# Altium schematic sheet [5]-USB.SchDoc
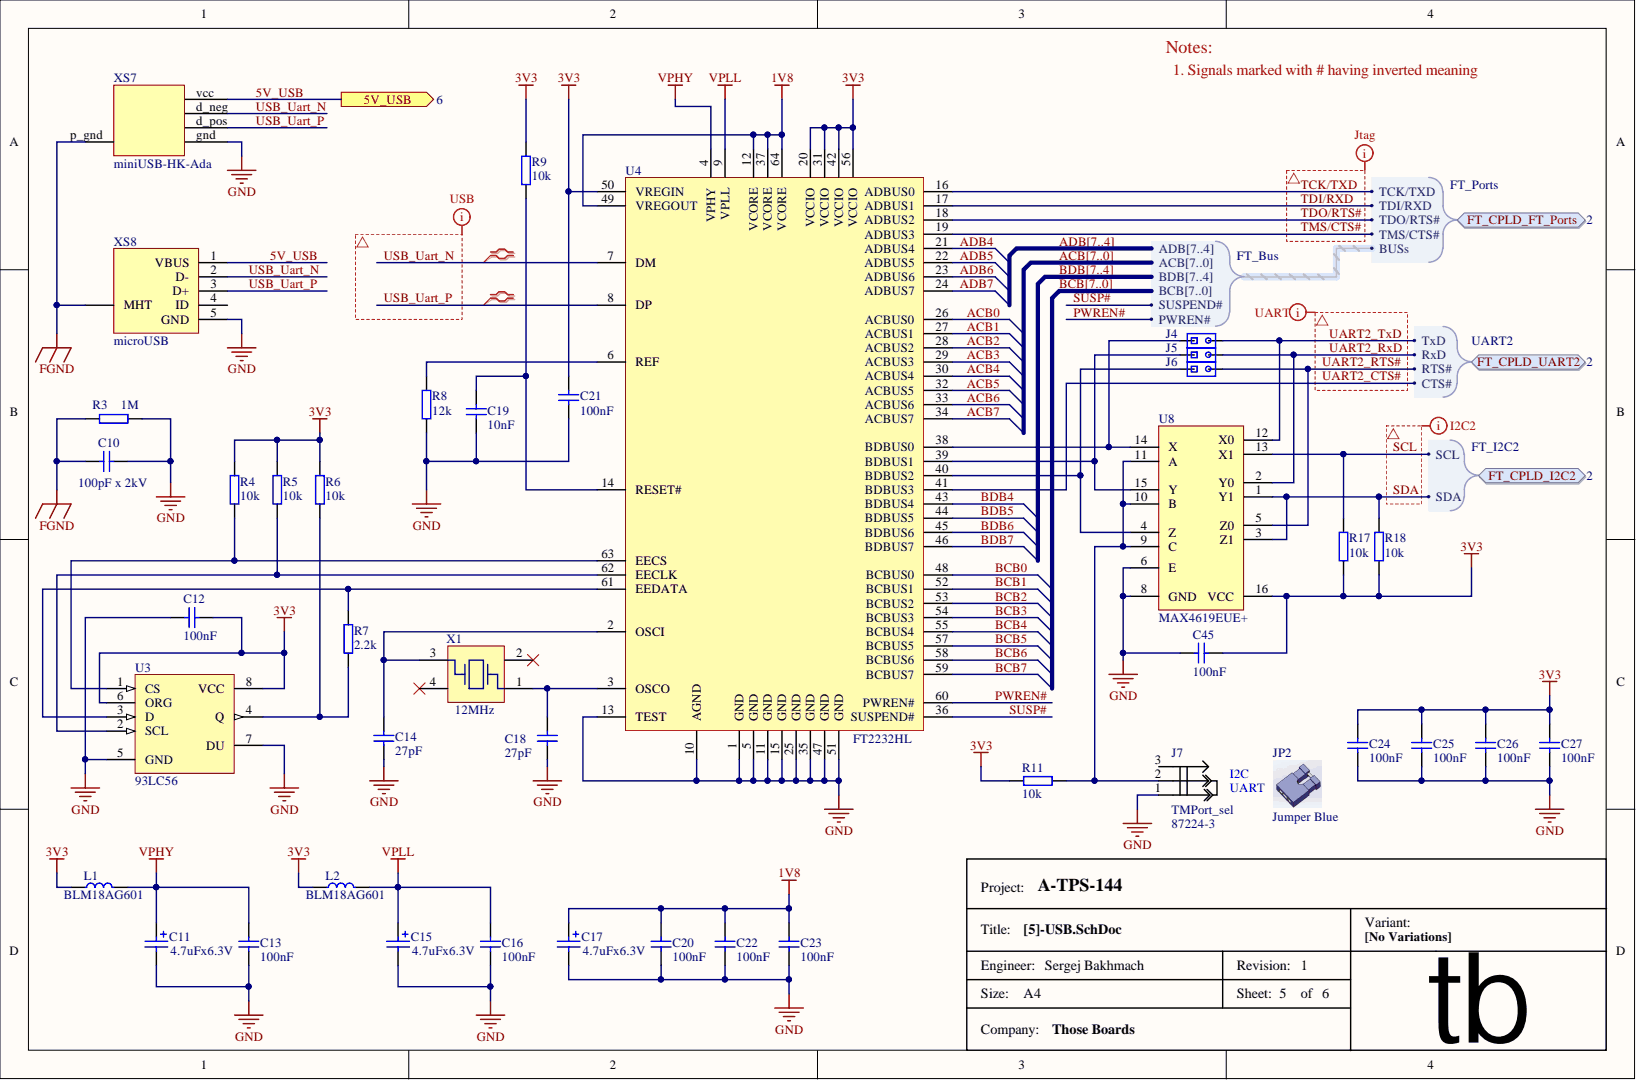
[diagram p0: [5]-USB.SchDoc]

## Parts
C10  value 100pF x 2kV  [C1210]  (hidden comment: CAP)
C11  value 4.7uFx6.3V  [CAP TAN 0805 2012 SIZE R]  (hidden comment: uF)
C12  value 100nF  [C0603]  (hidden comment: CAP)
C13  value 100nF  [C0603]  (hidden comment: CAP)
C14  value 27pF  [C0603]  (hidden comment: CAP)
C15  value 4.7uFx6.3V  [CAP TAN 0805 2012 SIZE R]  (hidden comment: uF)
C16  value 100nF  [C0603]  (hidden comment: CAP)
C17  value 4.7uFx6.3V  [CAP TAN 0805 2012 SIZE R]  (hidden comment: uF)
C18  value 27pF  [C0603]  (hidden comment: CAP)
C19  value 10nF  [C0603]  (hidden comment: CAP)
C20  value 100nF  [C0603]  (hidden comment: CAP)
C21  value 100nF  [C0603]  (hidden comment: CAP)
C22  value 100nF  [C0603]  (hidden comment: CAP)
C23  value 100nF  [C0603]  (hidden comment: CAP)
C24  value 100nF  [C0603]  (hidden comment: CAP)
C25  value 100nF  [C0603]  (hidden comment: CAP)
C26  value 100nF  [C0603]  (hidden comment: CAP)
C27  value 100nF  [C0603]  (hidden comment: CAP)
C45  value 100nF  [C0603]  (hidden comment: CAP)
J4  (no value)  [Jumper_smd_d3]  (no BOM)
J5  (no value)  [Jumper_smd_d3]  (no BOM)
J6  (no value)  [Jumper_smd_d3]  (no BOM)
J7  TMPort_sel  value 87224-3  Manufacturer Part: 87224-3  [Jumper_3pin]
JP2  value Jumper Blue  Supplier Part: Jumper  (hidden comment: VCC_sel)  (mechanical)
L1  value BLM18AG601  [ferrite_bead_0603]  (hidden comment: BLM18PG221SN1D)
L2  value BLM18AG601  [ferrite_bead_0603]  (hidden comment: BLM18PG221SN1D)
R3  value 1M  [0805_resistor]  (hidden comment: Resistor)
R4  value 10k  [0603_RESISTOR]  (hidden comment: Resistor)
R5  value 10k  [0603_RESISTOR]  (hidden comment: Resistor)
R6  value 10k  [0603_RESISTOR]  (hidden comment: Resistor)
R7  value 2.2k  [0603_RESISTOR]  (hidden comment: Resistor)
R8  value 12k  [0603_RESISTOR]  (hidden comment: Resistor)
R9  value 10k  [0603_RESISTOR]  (hidden comment: Resistor)
R11  value 10k  [0603_RESISTOR]  (hidden comment: Resistor)
R17  value 10k  [0603_RESISTOR]  (hidden comment: Resistor)
R18  value 10k  [0603_RESISTOR]  (hidden comment: Resistor)
U3  value 93LC56  [SOIC-8 150 Mil]  (hidden comment: 93xCxx)
U4  FT2232HL  [LQFP64]
U8  MAX4619EUE+  [TSSOP-16]
X1  value 12MHz  [OSC_CX3225GB]  (hidden comment: OSC_CX3225GB)
XS7  value miniUSB-HK-Ada  Manufacturer Part: miniUSB-HK-Ada  [MiniUSB_Conn_2types]  (hidden comment: miniUSB_conn)
XS8  microUSB  [MicroUSB47642-0001]

## Ports
5V_USB, FT_CPLD_FT_PORTS, FT_CPLD_FT_PORTS.BUSS.ACB0, FT_CPLD_FT_PORTS.BUSS.ACB1, FT_CPLD_FT_PORTS.BUSS.ACB2, FT_CPLD_FT_PORTS.BUSS.ACB3, FT_CPLD_FT_PORTS.BUSS.ACB4, FT_CPLD_FT_PORTS.BUSS.ACB5, FT_CPLD_FT_PORTS.BUSS.ACB6, FT_CPLD_FT_PORTS.BUSS.ACB7, FT_CPLD_FT_PORTS.BUSS.ACB[7..0], FT_CPLD_FT_PORTS.BUSS.ADB4, FT_CPLD_FT_PORTS.BUSS.ADB5, FT_CPLD_FT_PORTS.BUSS.ADB6, FT_CPLD_FT_PORTS.BUSS.ADB7, FT_CPLD_FT_PORTS.BUSS.ADB[7..4], FT_CPLD_FT_PORTS.BUSS.BCB0, FT_CPLD_FT_PORTS.BUSS.BCB1, FT_CPLD_FT_PORTS.BUSS.BCB2, FT_CPLD_FT_PORTS.BUSS.BCB3, FT_CPLD_FT_PORTS.BUSS.BCB4, FT_CPLD_FT_PORTS.BUSS.BCB5, FT_CPLD_FT_PORTS.BUSS.BCB6, FT_CPLD_FT_PORTS.BUSS.BCB7, FT_CPLD_FT_PORTS.BUSS.BCB[7..0], FT_CPLD_FT_PORTS.BUSS.BDB4, FT_CPLD_FT_PORTS.BUSS.BDB5, FT_CPLD_FT_PORTS.BUSS.BDB6, FT_CPLD_FT_PORTS.BUSS.BDB7, FT_CPLD_FT_PORTS.BUSS.BDB[7..4], FT_CPLD_FT_PORTS.BUSS.PWREN#, FT_CPLD_FT_PORTS.BUSS.SUSPEND#, FT_CPLD_FT_PORTS.TCK/TXD, FT_CPLD_FT_PORTS.TDI/RXD, FT_CPLD_FT_PORTS.TDO/RTS#, FT_CPLD_FT_PORTS.TMS/CTS#, FT_CPLD_I2C2, FT_CPLD_I2C2.SCL, FT_CPLD_I2C2.SDA, FT_CPLD_UART2, FT_CPLD_UART2.CTS#, FT_CPLD_UART2.RTS#, FT_CPLD_UART2.RXD, FT_CPLD_UART2.TXD

## Nets
1V8: C17.1 C20.2 C22.2 C23.2 U4.12(VCORE) U4.37(VCORE) U4.49(VREGOUT) U4.64(VCORE)
3V3: C12.1 C21.2 C24.2 C25.2 C26.2 C27.2 C45.1 L1.1 L2.1 R4.2 R5.2 R6.2 R9.2 R11.2 R17.1 R18.1 U3.6(ORG) U3.8(VCC) U4.20(VCCIO) U4.31(VCCIO) U4.42(VCCIO) U4.50(VREGIN) U4.56(VCCIO) U8.16(VCC)
FGND: C10.1 R3.1 XS7.p_gnd XS8.MHT
GND: C10.2 C11.2 C12.2 C13.1 C14.1 C15.2 C16.1 C17.2 C18.1 C19.1 C20.1 C21.1 C22.1 C23.1 C24.1 C25.1 C26.1 C27.1 C45.2 J7.1 R3.2 R8.1 U3.5(GND) U3.7(DU) U4.1(GND) U4.5(GND) U4.10(AGND) U4.11(GND) U4.13(TEST) U4.15(GND) U4.25(GND) U4.35(GND) U4.47(GND) U4.51(GND) U8.6(E) U8.8(GND) XS7.gnd XS8.5(GND)
VPHY: C11.1 C13.2 L1.2 U4.4(VPHY)
VPLL: C15.1 C16.2 L2.2 U4.9(VPLL)
5V_USB: XS7.vcc XS8.1(VBUS) ; port 5V_USB
ACB0: U4.26(ACBUS0) ; port FT_CPLD_FT_PORTS  [also labelled ACB]
ACB1: U4.27(ACBUS1) ; port FT_CPLD_FT_PORTS  [also labelled ACB]
ACB2: U4.28(ACBUS2) ; port FT_CPLD_FT_PORTS  [also labelled ACB]
ACB3: U4.29(ACBUS3) ; port FT_CPLD_FT_PORTS  [also labelled ACB]
ACB4: U4.30(ACBUS4) ; port FT_CPLD_FT_PORTS  [also labelled ACB]
ACB5: U4.32(ACBUS5) ; port FT_CPLD_FT_PORTS  [also labelled ACB]
ACB6: U4.33(ACBUS6) ; port FT_CPLD_FT_PORTS  [also labelled ACB]
ACB7: U4.34(ACBUS7) ; port FT_CPLD_FT_PORTS  [also labelled ACB]
ADB4: U4.21(ADBUS4) ; port FT_CPLD_FT_PORTS  [also labelled ADB]
ADB5: U4.22(ADBUS5) ; port FT_CPLD_FT_PORTS  [also labelled ADB]
ADB6: U4.23(ADBUS6) ; port FT_CPLD_FT_PORTS  [also labelled ADB]
ADB7: U4.24(ADBUS7) ; port FT_CPLD_FT_PORTS  [also labelled ADB]
BCB0: U4.48(BCBUS0) ; port FT_CPLD_FT_PORTS  [also labelled BCB]
BCB1: U4.52(BCBUS1) ; port FT_CPLD_FT_PORTS  [also labelled BCB]
BCB2: U4.53(BCBUS2) ; port FT_CPLD_FT_PORTS  [also labelled BCB]
BCB3: U4.54(BCBUS3) ; port FT_CPLD_FT_PORTS  [also labelled BCB]
BCB4: U4.55(BCBUS4) ; port FT_CPLD_FT_PORTS  [also labelled BCB]
BCB5: U4.57(BCBUS5) ; port FT_CPLD_FT_PORTS  [also labelled BCB]
BCB6: U4.58(BCBUS6) ; port FT_CPLD_FT_PORTS  [also labelled BCB]
BCB7: U4.59(BCBUS7) ; port FT_CPLD_FT_PORTS  [also labelled BCB]
BDB4: U4.43(BDBUS4) ; port FT_CPLD_FT_PORTS  [also labelled BDB]
BDB5: U4.44(BDBUS5) ; port FT_CPLD_FT_PORTS  [also labelled BDB]
BDB6: U4.45(BDBUS6) ; port FT_CPLD_FT_PORTS  [also labelled BDB]
BDB7: U4.46(BDBUS7) ; port FT_CPLD_FT_PORTS  [also labelled BDB]
PWREN#: U4.60(PWREN#) ; port FT_CPLD_FT_PORTS
SCL: R17.2 U8.13(X1) ; port FT_CPLD_I2C2
SDA: R18.2 U8.1(Y1) U8.3(Z1) ; port FT_CPLD_I2C2
SUSP#: U4.36(SUSPEND#) ; port FT_CPLD_FT_PORTS
TCK/TXD: U4.16(ADBUS0) ; port FT_CPLD_FT_PORTS
TDI/RXD: U4.17(ADBUS1) ; port FT_CPLD_FT_PORTS
TDO/RTS#: U4.18(ADBUS2) ; port FT_CPLD_FT_PORTS
TMS/CTS#: U4.19(ADBUS3) ; port FT_CPLD_FT_PORTS
UART2_CTS#: U4.41(BDBUS3) ; port FT_CPLD_UART2
UART2_RTS#: J6.2 U8.5(Z0) ; port FT_CPLD_UART2
UART2_RXD: J5.2 U8.2(Y0) ; port FT_CPLD_UART2
UART2_TXD: J4.2 U8.12(X0) ; port FT_CPLD_UART2
USB_UART_N: U4.7(DM) XS7.d_neg XS8.2(D-)
USB_UART_P: U4.8(DP) XS7.d_pos XS8.3(D+)
NetC14_2: C14.2 U4.2(OSCI) X1.3
NetC18_2: C18.2 U4.3(OSCO) X1.1
NetC19_2: C19.2 R9.1 U4.14(RESET#)
NetJ4_1: J4.1 U4.38(BDBUS0) U8.14(X)
NetJ5_1: J5.1 U4.39(BDBUS1) U8.15(Y)
NetJ6_1: J6.1 U4.40(BDBUS2) U8.4(Z)
NetJ7_2: J7.2 R11.1 U8.9(C) U8.10(B) U8.11(A)
NetR4_1: R4.1 U3.1(CS) U4.63(EECS)
NetR5_1: R5.1 U3.2(SCL) U4.62(EECLK)
NetR6_1: R6.1 R7.1 U3.4(Q)
NetR7_2: R7.2 U3.3(D) U4.61(EEDATA)
NetR8_2: R8.2 U4.6(REF)
N00136:
N00137:
N00138:
N00139:

Not connected: J7 3; X1 2 4; XS8 4(ID)

## Library data of the parts
C10 = CAP: Manufacturer: Murata Electronics; Manufacturer Part: GRM32A7U3D101JW31D; Type of Component: Ceramic Capacitor; Component Kind: Standard; Manufacturer Link: http://psearch.en.murata.com/capacitor/product/GRM32A7U3D101JW31%23.html; Package / Case: 1210; Supplier: mouser; Supplier Link: http://www.mouser.ee/ProductDetail/Murata-Electronics/GRM32A7U3D101JW31D/?qs=sGAEpiMZZMs0AnBnWHyRQFQYdTDrJniYFw4lyPz2MF4%3d; Supplier Part: 81-GRM32A7U3D101JW31; Library Name: RPI-VITA.IntLib
C11 C15 C17 = Cap_pol_tant: Manufacturer: AVX; Manufacturer Part: TAJR475K006RNJ; Type of Component: Tantalum Capacitor; Component Kind: Standard; Manufacturer Link: https://www.avx.com/prodinfo_productdetail.asp?I=81&K=search&C=&word=TAJR475K006RNJ&form=number&Number=; Package / Case: 0805; Supplier: mouser; Supplier Link: http://www.mouser.com/ProductDetail/AVX/TAJR475K006RNJ/?qs=sGAEpiMZZMuEN2agSAc2pmRv4r8cj6yFV16HxCaO%252bYg%3d; Supplier Part: 581-TAJR475K006RNJ; Library Name: RPI-VITA.IntLib
C12 C13 C16 C20-C27 C45 = CAP: Manufacturer: Vishay / Vitramon; Manufacturer Part: VJ0603Y104JXJPW1BC; Type of Component: Ceramic Capacitor; Component Kind: Standard; Manufacturer Link: http://www.vishay.com/product?docid=28548; Package / Case: 0603; Supplier: mouser; Supplier Link: http://www.mouser.com/ProductDetail/Vishay/VJ0603Y104JXJPW1BC/?qs=%2fha2pyFaduidGoO0X7aK7Xgs%2fjxfhnLiK6SRw4Lz0%252bZK3PJ8DddTrQ%3d%3d; Supplier Part: 77-VJ0603Y104JXJPBC; Library Name: RPI-VITA.IntLib
C14 C18 = CAP: Manufacturer: Vishay / Vitramon; Manufacturer Part: VJ0603A270GXAPW1BC; Type of Component: Ceramic Capacitor; Component Kind: Standard; Manufacturer Link: http://www.vishay.com/product?docid=28548; Package / Case: 0603; Supplier: mouser; Supplier Link: http://www.mouser.com/ProductDetail/Vishay-Vitramon/VJ0603A270GXAPW1BC/?qs=sGAEpiMZZMs0AnBnWHyRQCv4vfTUG%2fvHq9VjSt3ox9g%3d; Supplier Part: 77-VJ0603A270GXAPBC; Library Name: RPI-VITA.IntLib
C19 = CAP: Manufacturer: Vishay / Vitramon; Manufacturer Part: VJ0603Y103KXACW1BC; Type of Component: Ceramic Capacitor; Component Kind: Standard; Manufacturer Link: http://www.vishay.com/product?docid=28548; Package / Case: 0603; Supplier: mouser; Supplier Link: http://www.mouser.com/ProductDetail/Vishay-Vitramon/VJ0603Y103KXACW1BC/?qs=sGAEpiMZZMs0AnBnWHyRQNbg85K4ab%2f3XqRx2jQHGsc%3d; Supplier Part: 77-VJ0603Y103KXACBC; Library Name: RPI-VITA.IntLib
J4-J6 = jumper_2pin_pads: Library Name: RPI-VITA.IntLib
J7 = jumper_3pin: Manufacturer: TE Connectivity / AMP; Type of Component: Jumper; Component Kind: Standard; Manufacturer Link: http://www.te.com/usa-en/product-87224-3.html#pdp-related-products-inner; Package / Case: Header 1 x 3; Supplier: mouser; Supplier Link: http://www.mouser.ee/ProductDetail/TE-Connectivity-AMP/87224-3/?qs=sGAEpiMZZMs%252bGHln7q6pm48SVpWlpfsErguO%2fp0873w%3d; Supplier Part: 571-872243; Library Name: RPI-VITA.IntLib
JP2 = jumper blue: Manufacturer: Great Electric; Manufacturer Part: Jumper Cap Shunts Short Circuit Cap; Package / Case: 2.54mm; Supplier: aliexpress.com; Supplier Link: http://www.aliexpress.com/item/500pcs-lot-Asssorted-Color-2-54mm-Standard-Circuit-Board-Jumper-Cap-Shunts-Short-Circuit-Cap-CGKCH119/32344305619.html?spm=2114.03010208.3.80.nPPmoy&ws_ab_test=searchweb201556_1,searchweb201644_1_505_506_503_504_502_10001_10002_10017_10010_10005_10011_10006_10003_10004_10009_10007_10008,searchweb201560_5,searchweb1451318400_-1,searchweb1451318411_6452&btsid=16d11777-edde-4755-b8b5-9adacb495b09; Type of Component: Jumper; Library Name: RPI-VITA.IntLib
L1 L2 = BLM18PG221SN1D: ferrite bead 0603; Manufacturer: Murata; Manufacturer Part: BLM18AG601SN1D; Type of Component: EMI Filter Beads; Component Kind: Standard; Manufacturer Link: http://search.murata.co.jp/Ceramy/CatalogAction.do?sHinnm=BLM11A601S&sNHinnm=BLM18AG601SN1&sNhin_key=BLM18AG601SN1D&sLang=en&sParam=BLM18AG601SN1D; Package / Case: 0603; Supplier: mouser; Supplier Link: http://www.mouser.com/ProductDetail/Murata/BLM18AG601SN1D/?qs=%2fha2pyFadugaXrdTm9z6ujoHK9ziqVaZDl9lDPSbK0ptDeQWgq1exg%3d%3d; Supplier Part: 81-BLM11A601S; Library Name: RPI-VITA.IntLib
R3 = Resistor: Manufacturer: Vishay / Dale; Manufacturer Part: CRCW08051M00FKEA; Component Kind: Standard; Manufacturer Link: http://www.vishay.com/product?docid=20035; Package / Case: 0805; Supplier: mouser; Supplier Link: http://www2.mouser.com/ProductDetail/Vishay-Dale/CRCW08051M00FKEA/?qs=sGAEpiMZZMvdGkrng054txEw7b1YnvGubiAZzjveInE%3d; Supplier Part: 71-CRCW0805-1.0M-E3; Type of Component: Resistor; Library Name: RPI-VITA.IntLib
R4-R6 R9 R11 R17 R18 = Resistor: Manufacturer: Vishay / Dale; Manufacturer Part: CRCW060310K0FKEA; Component Kind: Standard; Manufacturer Link: http://www.vishay.com/product?docid=20035; Package / Case: 0603; Supplier: mouser; Supplier Link: http://www.mouser.com/ProductDetail/Vishay-Dale/CRCW060310K0FKEA/?qs=sGAEpiMZZMu61qfTUdNhGyptJL5EcUtREGTsEKG7Lak%3d; Supplier Part: 71-CRCW0603-10K-E3; Type of Component: Resistor; Library Name: RPI-VITA.IntLib
R7 = Resistor: Manufacturer: Vishay / Dale; Manufacturer Part: CRCW06032K20JNEA; Component Kind: Standard; Manufacturer Link: http://www.vishay.com/product?docid=20035; Package / Case: 0603; Supplier: mouser; Supplier Link: http://www.mouser.com/ProductDetail/Vishay-Dale/CRCW06032K20JNEA/?qs=sGAEpiMZZMvdGkrng054txEw7b1YnvGuRJLBQI6feSk%3d; Supplier Part: 71-CRCW0603J-2.2K-E3; Type of Component: Resistor; Library Name: RPI-VITA.IntLib
R8 = Resistor: Manufacturer: Vishay / Dale; Manufacturer Part: CRCW060312K0FKEA; Component Kind: Standard; Manufacturer Link: http://www.vishay.com/product?docid=20035; Package / Case: 0603; Supplier: mouser; Supplier Link: http://www.mouser.com/ProductDetail/Vishay-Dale/CRCW060312K0FKEA/?qs=sGAEpiMZZMu61qfTUdNhG%2fy1ga03FwuG5%2fz6Ysnsyas%3d; Supplier Part: 71-CRCW0603-12K-E3; Type of Component: Resistor; Library Name: RPI-VITA.IntLib
U3 = 93xCxx: eeprom; Manufacturer: Microchip Technology; Manufacturer Part: 93LC56CT-I/SN; Component Kind: Standard; Manufacturer Link: http://www.microchip.com/wwwproducts/Devices.aspx?product=93LC56C; Package / Case: SOIC-8; Supplier: mouser; Supplier Link: http://www.mouser.com/ProductDetail/Microchip-Technology/93LC56CT-I-SN/?qs=sGAEpiMZZMuVhdAcoizlRTtWxdtwgs5NlmtRRTBFNKE%3d; Supplier Part: 579-93LC56CT-I/SN; Type of Component: EEPROM; Library Name: RPI-VITA.IntLib
U4 = FT2232HL: Manufacturer: FTDI; Manufacturer Part: FT2232HL-TRAY; Type of Component: USB Interface IC; Component Kind: Standard; Manufacturer Link: http://www.ftdichip.com/Products/ICs/FT2232H.htm; Package / Case: LQFP-64; Supplier: mouser; Supplier Link: http://www.mouser.com/ProductDetail/FTDI/FT2232HL-TRAY/?qs=sGAEpiMZZMtv%252bwxsgy%2fhiMKIrlJio50yoVwto4siX0c%3d; Supplier Part: 895-FT2232HL-TRAY; Value: FT2232HL; Library Name: RPI-VITA.IntLib
U8 = MAX4619EUE+: High-Speed, Low-Voltage, CMOS Analog  Multiplexers/Switches; Manufacturer: Maxim Integrated; Type of Component: Analog Switch IC; Component Kind: Standard; Manufacturer Link: https://www.maximintegrated.com/en/products/analog/analog-switches-multiplexers/MAX4619.html; Manufacturer Part: MAX4619EUE+; Package / Case: TSSOP-16; Supplier: mouser; Supplier Link: http://www2.mouser.com/ProductDetail/Maxim-Integrated/MAX4619EUE+/?qs=sGAEpiMZZMvjbjwkTuU2aa02s6X86Mg5lA6G81ZX%252b98%3d; Supplier Part: 700-MAX4619EUE+; Value: MAX4619EUE+; Library Name: RPI-VITA.IntLib
X1 = OSC_CX3225GB: Manufacturer: Kyocera; Manufacturer Part: CX3225GB12000P0HPQCC; Type of Component: Crystal Quartz; Component Kind: Standard; Manufacturer Link: http://www.kyocera-crystal.jp/eng/prdct/list/xtal/; Package / Case: 3.2 mm x 2.5 mm; Supplier: mouser; Supplier Link: http://www.mouser.com/ProductDetail/AVX/CX3225GB12000P0HPQCC/?qs=sGAEpiMZZMsBj6bBr9Q9aY2qtJ4G5VulKoMshKcCM6ORlbreQkoE2A%3d%3d; Supplier Part: 581-CX3225GB12PHPQCC; Library Name: RPI-VITA.IntLib
XS7 = miniUSB_conn: mini USB Connector; Manufacturer: HK-Ada; Type of Component: Connector miniUSB; Component Kind: Standard; Package / Case: miniUSB; Supplier: HK-Ada; Library Name: RPI-VITA.IntLib
XS8 = microUSB_conn: mini USB Connector; Type of Component: Connector microUSB; Value: microUSB 5p; Component Kind: Standard; Package / Case: 5 pin; Supplier: aliexpress.com; Supplier Link: aliexpress.com/item/50PCS-10-PCS-LOT-free-shippingAll-copper-MicroUSB-socket-MK5P-Mike-5P-MINIUSB-Micro-USB-female/32310556625.html?spm=2114.03010208.3.185.9X3VVt&ws_ab_test=searchweb201556_1,searchweb201644_1_505_506_503_504_502_10001_10002_10017_10010_10005_10011_10006_10003_10004_10009_10007_10008,searchweb201560_5,searchweb1451318400_-1,searchweb1451318411_6452&btsid=8bbba286-9188-46c9-84b7-d50fd2d22579; Library Name: RPI-VITA.IntLib
